# Altium schematic sheet NXP NXPhlite-Sensors 3.0RC18.SchDoc
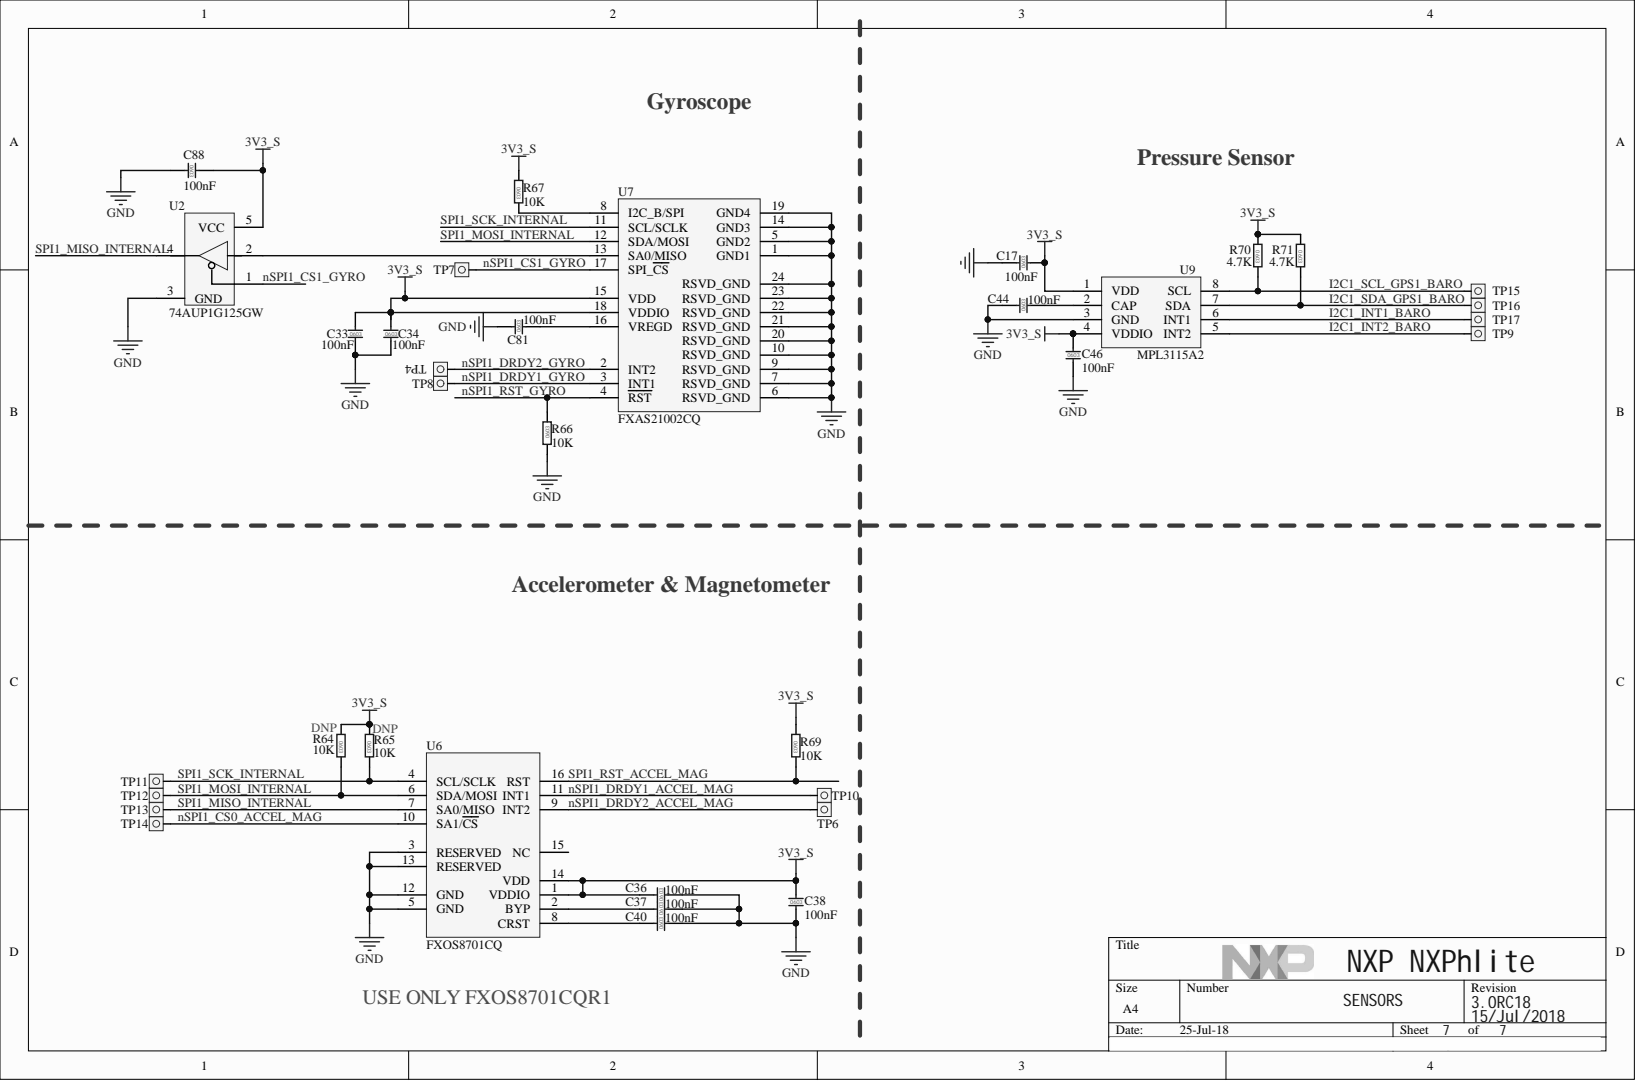
[diagram p0: NXP NXPhlite-Sensors 3.0RC18.SchDoc]

## Parts
C17  100nF  [0603C]
C33  100nF  [0603C]
C34  100nF  [0603C]
C36  100nF  [0603C]
C37  100nF  [0603C]
C38  100nF  [0603C]
C40  100nF  [0603C]
C44  100nF  [0603C]
C46  100nF  [0603C]
C81  100nF  [0603C]
C88  100nF  [0603C]
R64  10K  [0603R]
R65  10K  [0603R]
R66  10K  [0603R]
R67  10K  [0603R]
R69  10K  [0603R]
R70  4.7K  [0603R]
R71  4.7K  [0603R]
TP4  TP  [TP_0.8]
TP6  TP  [TP_0.8]
TP7  TP  [TP_0.8]
TP8  TP  [TP_0.8]
TP9  TP  [TP_0.8]
TP10  TP  [TP_0.8]
TP11  TP  [TP_0.8]
TP12  TP  [TP_0.8]
TP13  TP  [TP_0.8]
TP14  TP  [TP_0.8]
TP15  TP  [TP_0.8]
TP16  TP  [TP_0.8]
TP17  TP  [TP_0.8]
U2  74AUP1G125GW  [SOT353-1]
U6  FXOS8701CQ  [QFN16(FXOS8700CQ)]
U7  FXAS21002CQ  [QFN24(FXAS21002CQ)]
U9  MPL3115A2  [LGA8(MPL3115A2)]

## Nets
3V3_S: C17.2 C33.1 C34.1 C36.1 C38.1 C46.1 C88.1 R64.2 R65.2 R67.2 R69.2 R70.2 R71.2 U2.5(VCC) U6.1(VDDIO) U6.14(VDD) U7.15(VDD) U7.18(VDDIO) U9.1(VDD) U9.4(VDDIO)
GND: C17.1 C33.2 C34.2 C36.2 C37.2 C38.2 C40.2 C44.1 C46.2 C81.1 C88.2 R66.2 U2.3(GND) U6.3(RESERVED) U6.5(GND) U6.12(GND) U6.13(RESERVED) U7.1(GND1) U7.5(GND2) U7.6(RSVD_GND) U7.7(RSVD_GND) U7.9(RSVD_GND) U7.10(RSVD_GND) U7.14(GND3) U7.19(GND4) U7.20(RSVD_GND) U7.21(RSVD_GND) U7.22(RSVD_GND) U7.23(RSVD_GND) U7.24(RSVD_GND) U9.3(GND)
I2C1_INT1_BARO: TP17.1 U9.6(INT1)
I2C1_INT2_BARO: TP9.1 U9.5(INT2)
I2C1_SCL_GPS1_BARO: R70.1 TP15.1 U9.8(SCL)
I2C1_SDA_GPS1_BARO: R71.1 TP16.1 U9.7(SDA)
nSPI1_CS0_ACCEL_MAG: TP14.1 U6.10(SA1/CS)
nSPI1_CS1_GYRO: TP7.1 U2.1 U7.17(SPI_CS)
nSPI1_DRDY1_ACCEL_MAG: TP10.1 U6.11(INT1)
nSPI1_DRDY1_GYRO: TP8.1 U7.3(INT1)
nSPI1_DRDY2_ACCEL_MAG: TP6.1 U6.9(INT2)
nSPI1_DRDY2_GYRO: TP4.1 U7.2(INT2)
nSPI1_RST_GYRO: R66.1 U7.4(RST)
SPI1_MISO_INTERNAL: TP13.1 U2.4 U6.7(SA0/MISO)
SPI1_MOSI_INTERNAL: R64.1 TP12.1 U6.6(SDA/MOSI) U7.12(SDA/MOSI)
SPI1_RST_ACCEL_MAG: R69.1 U6.16(RST)
SPI1_SCK_INTERNAL: R65.1 TP11.1 U6.4(SCL/SCLK) U7.11(SCL/SCLK)
NetC37_1: C37.1 U6.2(BYP)
NetC40_1: C40.1 U6.8(CRST)
NetC44_2: C44.2 U9.2(CAP)
NetC81_2: C81.2 U7.16(VREGD)
NetR67_1: R67.1 U7.8(I2C_B/SPI)
NetU2_2: U2.2 U7.13(SA0/MISO)

Not connected: U6 15(NC)

## Library data of the parts
C17 C33 C34 C36-C38 C40 C44 C46 C81 C88 = Cap_0603: CAP CER 0.1uF 16V 10% X7R AEC-Q200 0603; Manufacturer: MURATA; Manufacturer PN: GCM188R71C104KA37D; Agile PN: 150-79338; Component Kind: Standard; Library Name: LZ SchLib V1.0.SchLib
R64 R65 = Res_0603: Resistor; Component Kind: Standard; Library Name: LZ SchLib V1.0.SchLib
R66 R67 R69 = Res_0603: RES MF 10.0K 1/10W 1% 0603; Manufacturer: Yageo; Manufacturer PN: RC0603FR-0710KL; Agile PN: 470-75422; Component Kind: Standard; Library Name: LZ SchLib V1.0.SchLib
R70 R71 = Res_0603: RES MF 4.7K 1/10W 1% AEC-Q200 0603; Manufacturer: KOA SPEER; Manufacturer PN: RK73H1JTTD4701F; Agile PN: 470-81511; Component Kind: Standard; Library Name: LZ SchLib V1.0.SchLib
U2 = 74AUP1G125GW: C BUFFER/LINE DRIVER 3STATE 0.8-3.6V SC70-5; Manufacturer: Nexperia; Manufacturer PN: 74AUP1G125GW,125; Agile PN: 315-80956; Component Kind: Standard; Library Name: NXP NXPhlite V3.2.SCHLIB
U6 = FXOS8701CQ: 3-AXIS MAG 3-AXIS ACCEL; Manufacturer: NXP SEMICONDUCTORS; Manufacturer PN: PFXOS8701CQR1; Agile PN: TBD; Component Kind: Standard; Library Name: NXP NXPhlite V3.2.SCHLIB
U7 = FXAS21002CQ: IC XTRINSIC 3-AXIS DIGITAL GYROSCOPE 1.95-3.6V QFN24; Manufacturer: NXP SEMICONDUCTORS; Agile PN: 312-81029; Component Kind: Standard; Library Name: Schlib1.SchLib; Manufacturer PN: FXAS21002CQ
U9 = MPL3115A2: IC ALTIMETER I2C 50-115KPA 1.96-3.6V LGA8; Manufacturer: NXP SEMICONDUCTORS; Agile PN: 315-79136; Component Kind: Standard; Library Name: Schlib1.SchLib; Manufacturer PN: MPL3115A2
TP4 TP6-TP17 = TP: Component Kind: Standard; Library Name: LZ SchLib V1.0.SchLib
